# Altium schematic sheet eRatPlatform_2.SchDoc
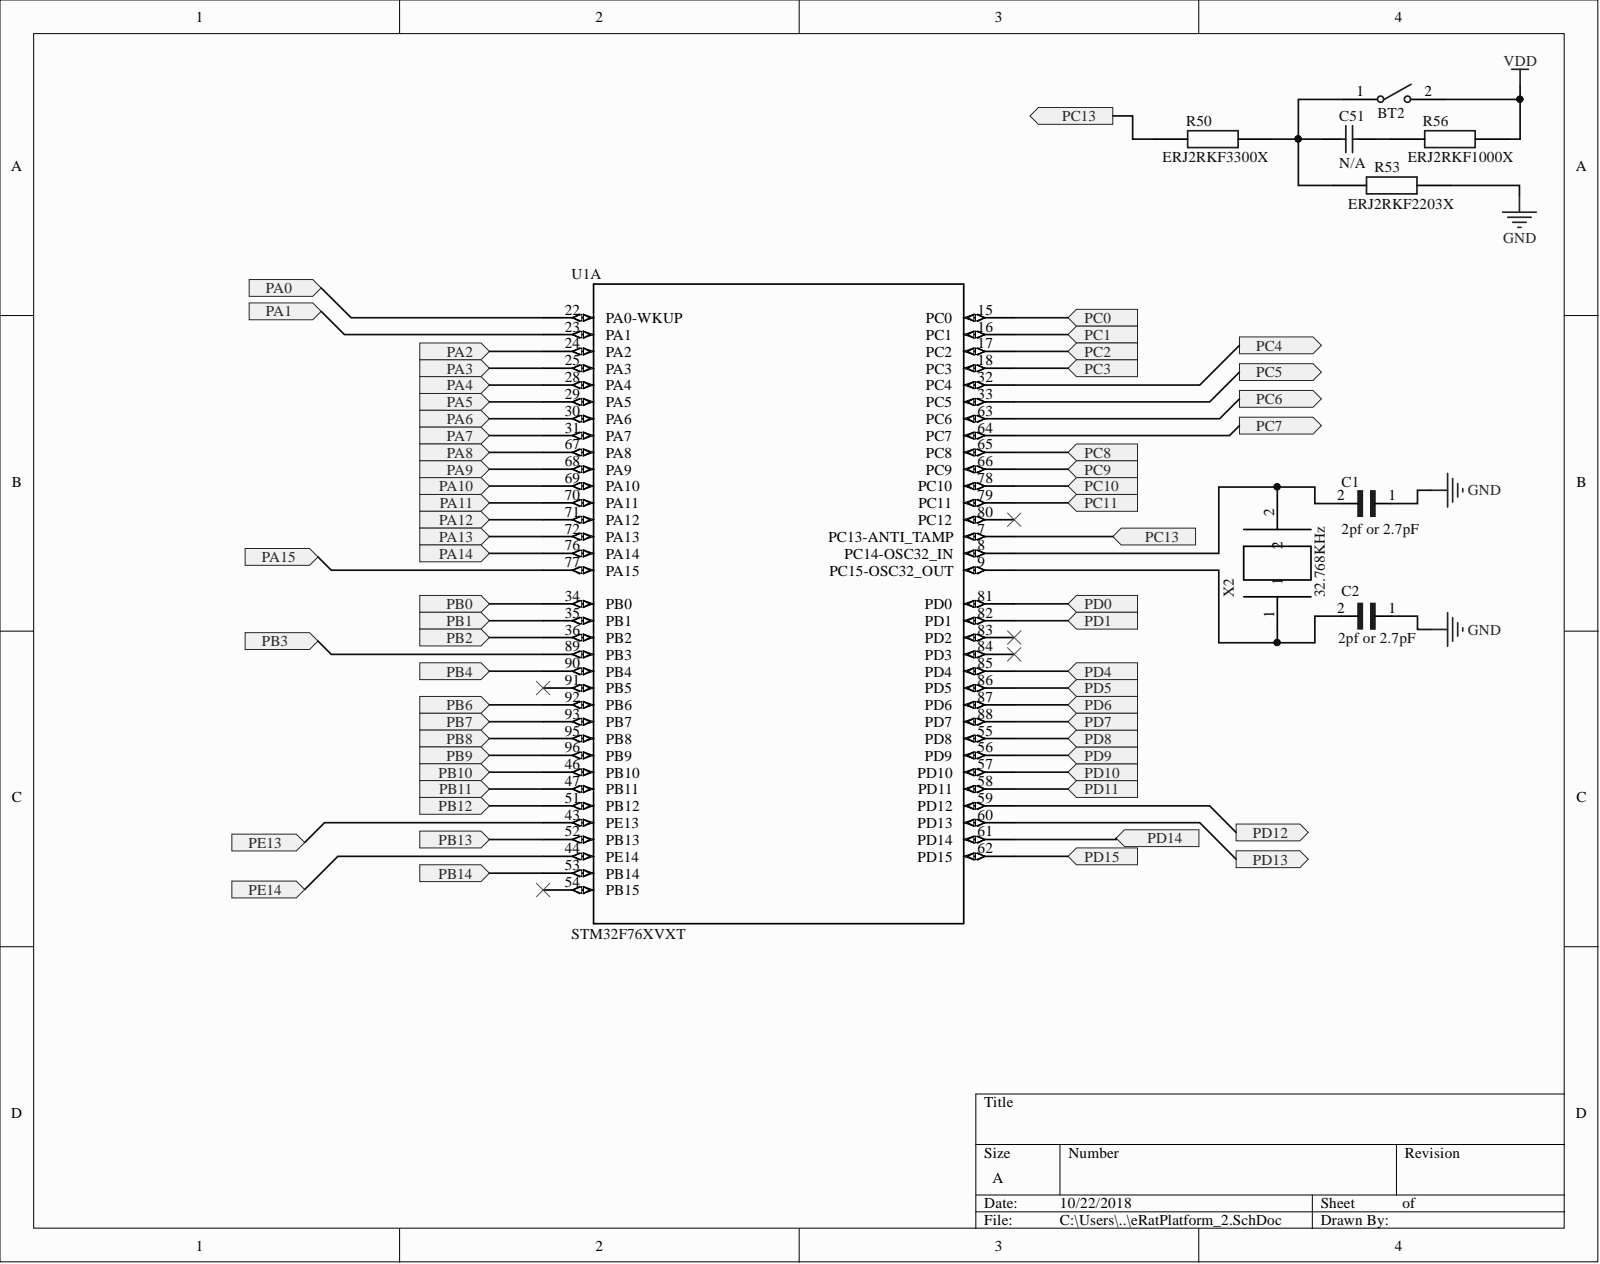
[diagram p0: eRatPlatform_2.SchDoc]

## Parts
BT2  434123050816  [434123050816]  (value not drawn)
C1  2pf or 2.7pF  [CAPC1005X55N]
C2  2pf or 2.7pF  [CAPC1005X55N]
C51  N/A  [1206_3.2x1.6x0.8mm]
R50  ERJ2RKF3300X  [RESC1005X40N]
R53  ERJ2RKF2203X  [RESC1005X40N]
R56  ERJ2RKF1000X  [RESC1005X40N]
U1  STM32F76XVXT  [LQFP-100_14X14mm]  (part A)
X2  32.768KHz  [XTAL320X150X90]

## Ports
PA0, PA1, PA2, PA3, PA4, PA5, PA6, PA7, PA8, PA9, PA10, PA11, PA12, PA13, PA14, PA15, PB0, PB1, PB2, PB3, PB4, PB6, PB7, PB8, PB9, PB10, PB11, PB12, PB13, PB14, PC0, PC1, PC2, PC3, PC4, PC5, PC6, PC7, PC8, PC9, PC10, PC11, PC13, PD0, PD1, PD4, PD5, PD6, PD7, PD8, PD9, PD10, PD11, PD12, PD13, PD14, PD15, PE13, PE14

## Nets
GND: C1.1 C2.1 R53.1
VDD: BT2.2 R56.2
PA0: U1.22(PA0-WKUP) ; port PA0
PA1: U1.23(PA1) ; port PA1
PA2: U1.24(PA2) ; port PA2
PA3: U1.25(PA3) ; port PA3
PA4: U1.28(PA4) ; port PA4
PA5: U1.29(PA5) ; port PA5
PA6: U1.30(PA6) ; port PA6
PA7: U1.31(PA7) ; port PA7
PA8: U1.67(PA8) ; port PA8
PA9: U1.68(PA9) ; port PA9
PA10: U1.69(PA10) ; port PA10
PA11: U1.70(PA11) ; port PA11
PA12: U1.71(PA12) ; port PA12
PA13: U1.72(PA13) ; port PA13
PA14: U1.76(PA14) ; port PA14
PA15: U1.77(PA15) ; port PA15
PB0: U1.34(PB0) ; port PB0
PB1: U1.35(PB1) ; port PB1
PB2: U1.36(PB2) ; port PB2
PB3: U1.89(PB3) ; port PB3
PB4: U1.90(PB4) ; port PB4
PB6: U1.92(PB6) ; port PB6
PB7: U1.93(PB7) ; port PB7
PB8: U1.95(PB8) ; port PB8
PB9: U1.96(PB9) ; port PB9
PB10: U1.46(PB10) ; port PB10
PB11: U1.47(PB11) ; port PB11
PB12: U1.51(PB12) ; port PB12
PB13: U1.52(PB13) ; port PB13
PB14: U1.53(PB14) ; port PB14
PC0: U1.15(PC0) ; port PC0
PC1: U1.16(PC1) ; port PC1
PC2: U1.17(PC2) ; port PC2
PC3: U1.18(PC3) ; port PC3
PC4: U1.32(PC4) ; port PC4
PC5: U1.33(PC5) ; port PC5
PC6: U1.63(PC6) ; port PC6
PC7: U1.64(PC7) ; port PC7
PC8: U1.65(PC8) ; port PC8
PC9: U1.66(PC9) ; port PC9
PC10: U1.78(PC10) ; port PC10
PC11: U1.79(PC11) ; port PC11
PC13: R50.1 U1.7(PC13-ANTI_TAMP) ; port PC13
PD0: U1.81(PD0) ; port PD0
PD1: U1.82(PD1) ; port PD1
PD4: U1.85(PD4) ; port PD4
PD5: U1.86(PD5) ; port PD5
PD6: U1.87(PD6) ; port PD6
PD7: U1.88(PD7) ; port PD7
PD8: U1.55(PD8) ; port PD8
PD9: U1.56(PD9) ; port PD9
PD10: U1.57(PD10) ; port PD10
PD11: U1.58(PD11) ; port PD11
PD12: U1.59(PD12) ; port PD12
PD13: U1.60(PD13) ; port PD13
PD14: U1.61(PD14) ; port PD14
PD15: U1.62(PD15) ; port PD15
PE13: U1.43(PE13) ; port PE13
PE14: U1.44(PE14) ; port PE14
NetBT2_1: BT2.1 C51.1 R50.2 R53.2
NetC1_2: C1.2 U1.8(PC14-OSC32_IN) X2.2
NetC2_2: C2.2 U1.9(PC15-OSC32_OUT) X2.1
NetC51_2: C51.2 R56.1

Not connected: U1 54(PB15) 80(PC12) 83(PD2) 84(PD3) 91(PB5)

## Library data of the parts
BT2 = 434123050816: 6x3.5mm SMD-J-Bend WS-TASV, height 5 mm, 160 gf; Operation Force: 160 gf; Rated Current: 50 mA; Rated Voltage: 12 VDC; Component Kind: Standard; ComponentLink1Description: Manufacturer; ComponentLink1URL: http://www.we-online.de; ComponentLink2Description: Datasheet; ComponentLink2URL: http://katalog.we-online.de/em/datasheet/4341x3xxx8x6.pdf; Library Name: ALTIUM-WS-TASV-rev17b.IntLib; Life Cycle: 50000; Operating Temperature: -40 to +85 degC; Part Number: 434123050816; Published Date: Nov. 2017; Size: 6x3.5x5 mm; Publisher: Wurth Elektronik
C1 C2 = C0402C209B5GACTU: Cap Ceramic 2pF 50V C0G 0.1pF SMD 0402 125Â°C Paper T/R; MF: KEMET; Availability: Good; Component Kind: Standard; Library Name: C0402C209B5GACTU.IntLib; MP: C0402C209B5GACTU; Package: 0402 KEMET; Price: 0.17 USD; SnapEDA_Link: https://www.snapeda.com/parts/C0402C209B5GACTU/KEMET/view-part/?ref=snap
C51 = 885012208030: WCAP-CSGP Ceramic Capacitors, 1206, X7R, 16V, 100nF; Rated Voltage: 16V DC; Ceramic Type: X7R; Component Kind: Standard; ComponentLink1Description: Manufacturer; ComponentLink1URL: http://www.we-online.de; ComponentLink2Description: Datasheet; ComponentLink2URL: http://katalog.we-online.de/pbs/datasheet/885012208030.pdf; Library Name: ALTIUM-WCAP-CSGP-1206-rev17b.IntLib; Operating Temperature: -55 °C to +125 °C; Part Number: 885012208030; Published Date: Oct. 2017; Size: 1206; Value: 100nF; Publisher: Wurth Elektronik
R50 = ERJ2RKF3300X: Panasonic ERJ2RK Series Thick Film Surface Mount Fixed Resistor 0402 Case 1% 0.1W 100ppm/C; Allied_Number: 70265140; Geometry.Height: 0.4mm; Allied Price/Stock: https://www.alliedelec.com/panasonic-erj-2rkf3300x/70265140/; Arrow Part Number: ERJ2RKF3300X; Arrow Price/Stock: http://uk.rs-online.com/web/p/products/7325444; Component Kind: Standard; Datasheet Link: http://docs-europe.electrocomponents.com/webdocs/13ba/0900766b813ba21b.pdf; Library Name: ERJ2RKF3300X.IntLib; Manufacturer_Name: Panasonic; Manufacturer_Part_Number: ERJ2RKF3300X; RS Part Number: 7325444; RS Price/Stock: http://uk.rs-online.com/web/p/products/7325444
R53 = ERJ2RKF2203X: Panasonic ERJ2RK Series Thick Film Surface Mount Fixed Resistor 402 Case 220k 1% 0.1W 100ppm/C; Allied_Number: 70265127; Geometry.Height: 0.4mm; Allied Price/Stock: https://www.alliedelec.com/panasonic-erj-2rkf2203x/70265127/; Arrow Part Number: ERJ2RKF2203X; Arrow Price/Stock: http://uk.rs-online.com/web/p/products/7325381; Component Kind: Standard; Datasheet Link: http://docs-europe.electrocomponents.com/webdocs/13ba/0900766b813ba21b.pdf; Library Name: ERJ2RKF2203X.IntLib; Manufacturer_Name: Panasonic; Manufacturer_Part_Number: ERJ2RKF2203X; RS Part Number: 7325381; RS Price/Stock: http://uk.rs-online.com/web/p/products/7325381
R56 = ERJ2RKF1000X: Panasonic ERJ2RK Series Thick Film Surface Mount Fixed Resistor 0402 Case 1% 0.1W 100ppm/C; Allied_Number: 70265091; Geometry.Height: 0.4mm; Allied Price/Stock: https://www.alliedelec.com/panasonic-erj-2rkf1000x/70265091/; Arrow Part Number: ERJ2RKF1000X; Arrow Price/Stock: http://uk.rs-online.com/web/p/products/6650695; Component Kind: Standard; Datasheet Link: http://docs-europe.electrocomponents.com/webdocs/13ba/0900766b813ba21b.pdf; Library Name: ERJ2RKF1000X.IntLib; Manufacturer_Name: Panasonic; Manufacturer_Part_Number: ERJ2RKF1000X; RS Part Number: 6650695; RS Price/Stock: http://uk.rs-online.com/web/p/products/6650695
U1 = STM32F76XVXT: Imported; C: Copyright (C) 2016 Accelerated Designs. All rights reserved; Vendor: STMicroelectronics; Component Kind: Standard; Library Name: STM32F767VIT6.IntLib; Manufacturer_Part_Number: stm32f76xvxt; RefDes: RefDes; Type: Type; Value: Value; Copyright: Copyright (C) 2016 Accelerated Designs. All rights reserved
X2 = ABS07AIG-32.768KHZ-9-1-T: Crystal 32.768khz 9pf Smd; MF: Abracon; Component Kind: Standard; Digi-Key_Part_Number: 535-13353-1-ND; Digi-Key_Purchase_URL: https://www.digikey.pl/product-detail/en/abracon-llc/ABS07AIG-32.768KHZ-9-1-T/535-13353-1-ND/5817607?utm_source=snapeda&utm_medium=aggregator&utm_campaign=symbol; Library Name: ABS07AIG-32.768KHZ-9-1-T.IntLib; MP: ABS07AIG-32.768KHZ-9-1-T; Package: SMD-2 Abracon; SnapEDA_Link: https://www.snapeda.com/parts/ABS07AIG-32.768KHZ-9-1-T/Abracon%20LLC/view-part/?ref=snap
